# Altium schematic sheet 0.96OLED.schdoc
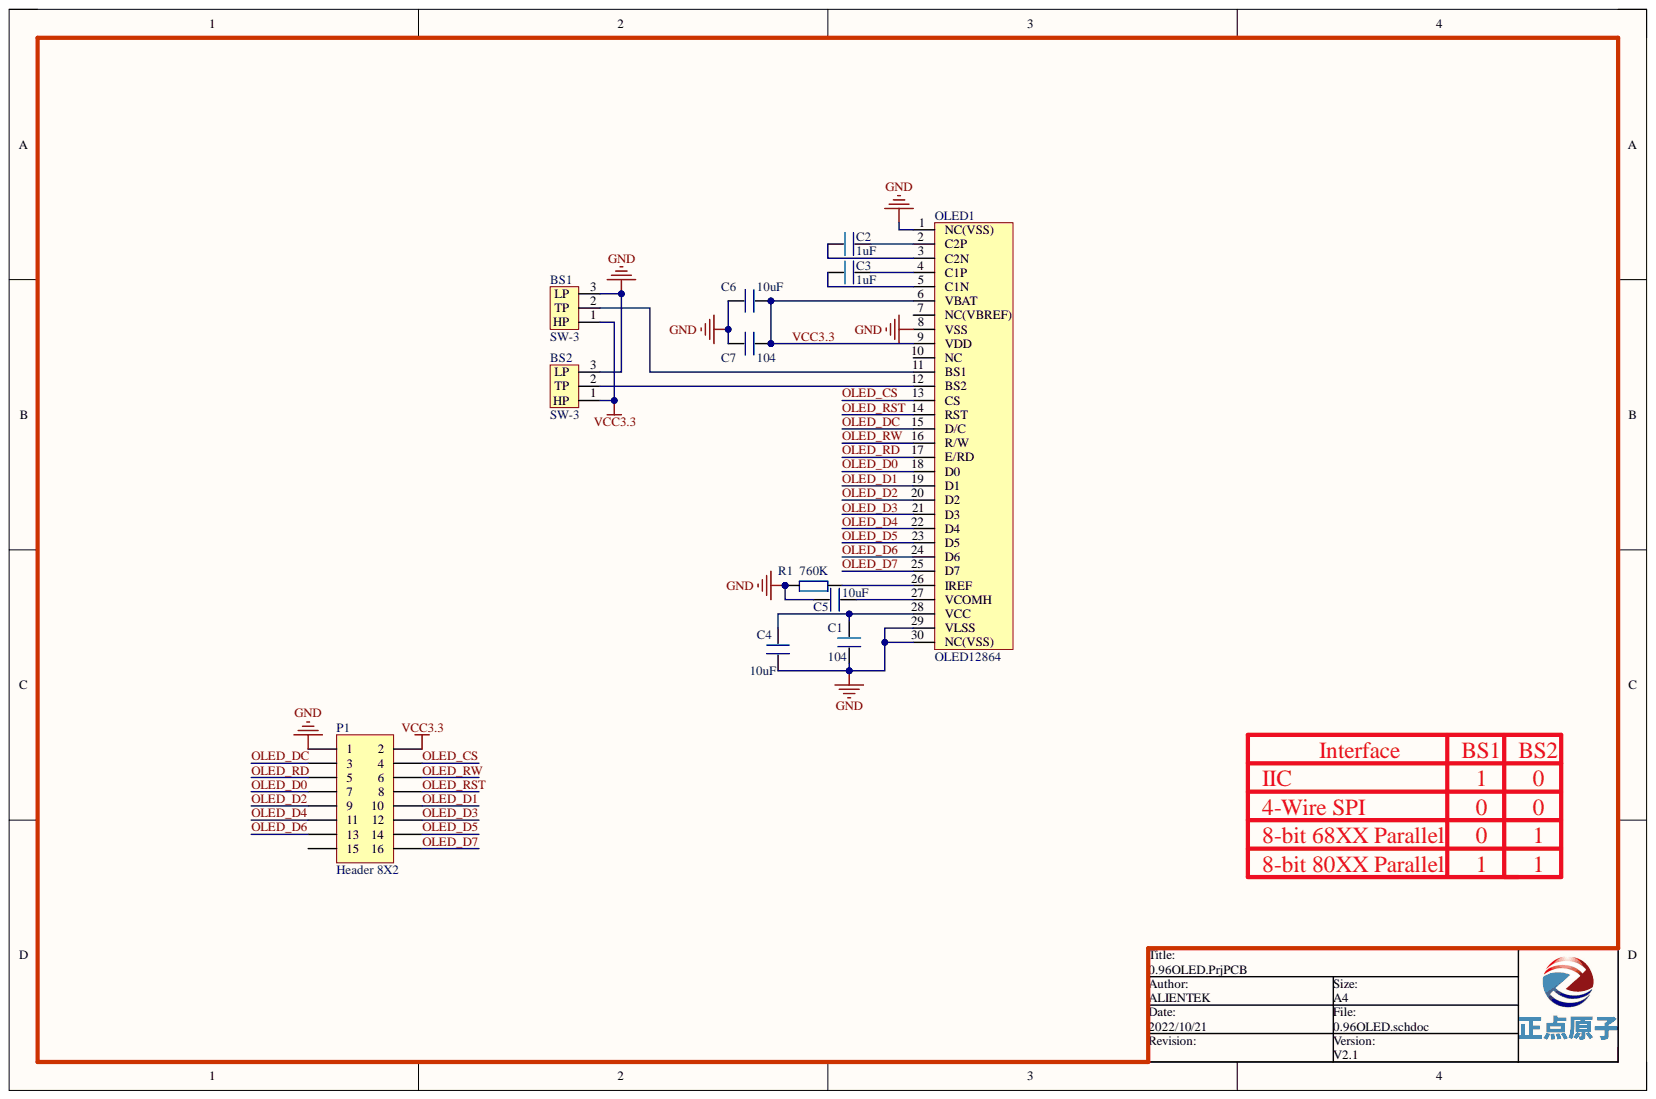
[diagram p0: 0.96OLED.schdoc]

## Parts
BS1  SW-3  [SW-3]
BS2  SW-3  [SW-3]
C1  104  [0603P]
C2  1uF  [0603P]
C3  1uF  [0603P]
C4  10uF  [0805]
C5  10uF  [0805]
C6  10uF  [0805]
C7  104  [0603P]
OLED1  OLED12864  [OLED_0.96]
P1  Header 8X2  [HDR2X8]
R1  760K  [0603P]

## Nets
GND: BS1.3 BS2.3 C1.1 C4.1 C5.1 C6.1 C7.1 OLED1.1(NC(VSS)) OLED1.8(VSS) OLED1.29(VLSS) OLED1.30(NC(VSS)) P1.1 R1.1
OLED_CS: OLED1.13(CS) P1.4
OLED_D0: OLED1.18(D0) P1.7
OLED_D1: OLED1.19(D1) P1.10
OLED_D2: OLED1.20(D2) P1.9
OLED_D3: OLED1.21(D3) P1.12
OLED_D4: OLED1.22(D4) P1.11
OLED_D5: OLED1.23(D5) P1.14
OLED_D6: OLED1.24(D6) P1.13
OLED_D7: OLED1.25(D7) P1.16
OLED_DC: OLED1.15(D/C) P1.3
OLED_RD: OLED1.17(E/RD) P1.5
OLED_RST: OLED1.14(RST) P1.8
OLED_RW: OLED1.16(R/W) P1.6
VCC3.3: BS1.1 BS2.1 C6.2 C7.2 OLED1.6(VBAT) OLED1.9(VDD) P1.2
NetBS1_2: BS1.2 OLED1.11(BS1)
NetBS2_2: BS2.2 OLED1.12(BS2)
NetC1_2: C1.2 C4.2 OLED1.28(VCC)
NetC2_1: C2.1 OLED1.3(C2N)
NetC2_2: C2.2 OLED1.2(C2P)
NetC3_1: C3.1 OLED1.5(C1N)
NetC3_2: C3.2 OLED1.4(C1P)
NetC5_2: C5.2 OLED1.27(VCOMH)
NetOLED1_26: OLED1.26(IREF) R1.2

Not connected: OLED1 7(NC(VBREF)) 10(NC); P1 15

## Library data of the parts
BS1 BS2 = SW: Component Kind: Standard; Description: SW-3; Library Name: MYCOMLIB.IntLib
C1-C7 = C: Component Kind: Standard; Library Name: MYCOMLIB.IntLib
P1 = Header 8X2: Header, 8-Pin, Dual row; Component Kind: Standard; LatestRevisionDate: 17-Jul-2002; LatestRevisionNote: Re-released for DXP Platform.; Library Name: Miscellaneous Connectors.IntLib; Signal Integrity: Connector; Publisher: Altium Limited
R1 = R: Component Kind: Standard; Library Name: MYCOMLIB.IntLib
OLED1 = OLED12864: Component Kind: Standard; Library Name: MYCOMLIB.IntLib
